# Altium schematic sheet PISCES_200.SchDoc(PISCES_200)
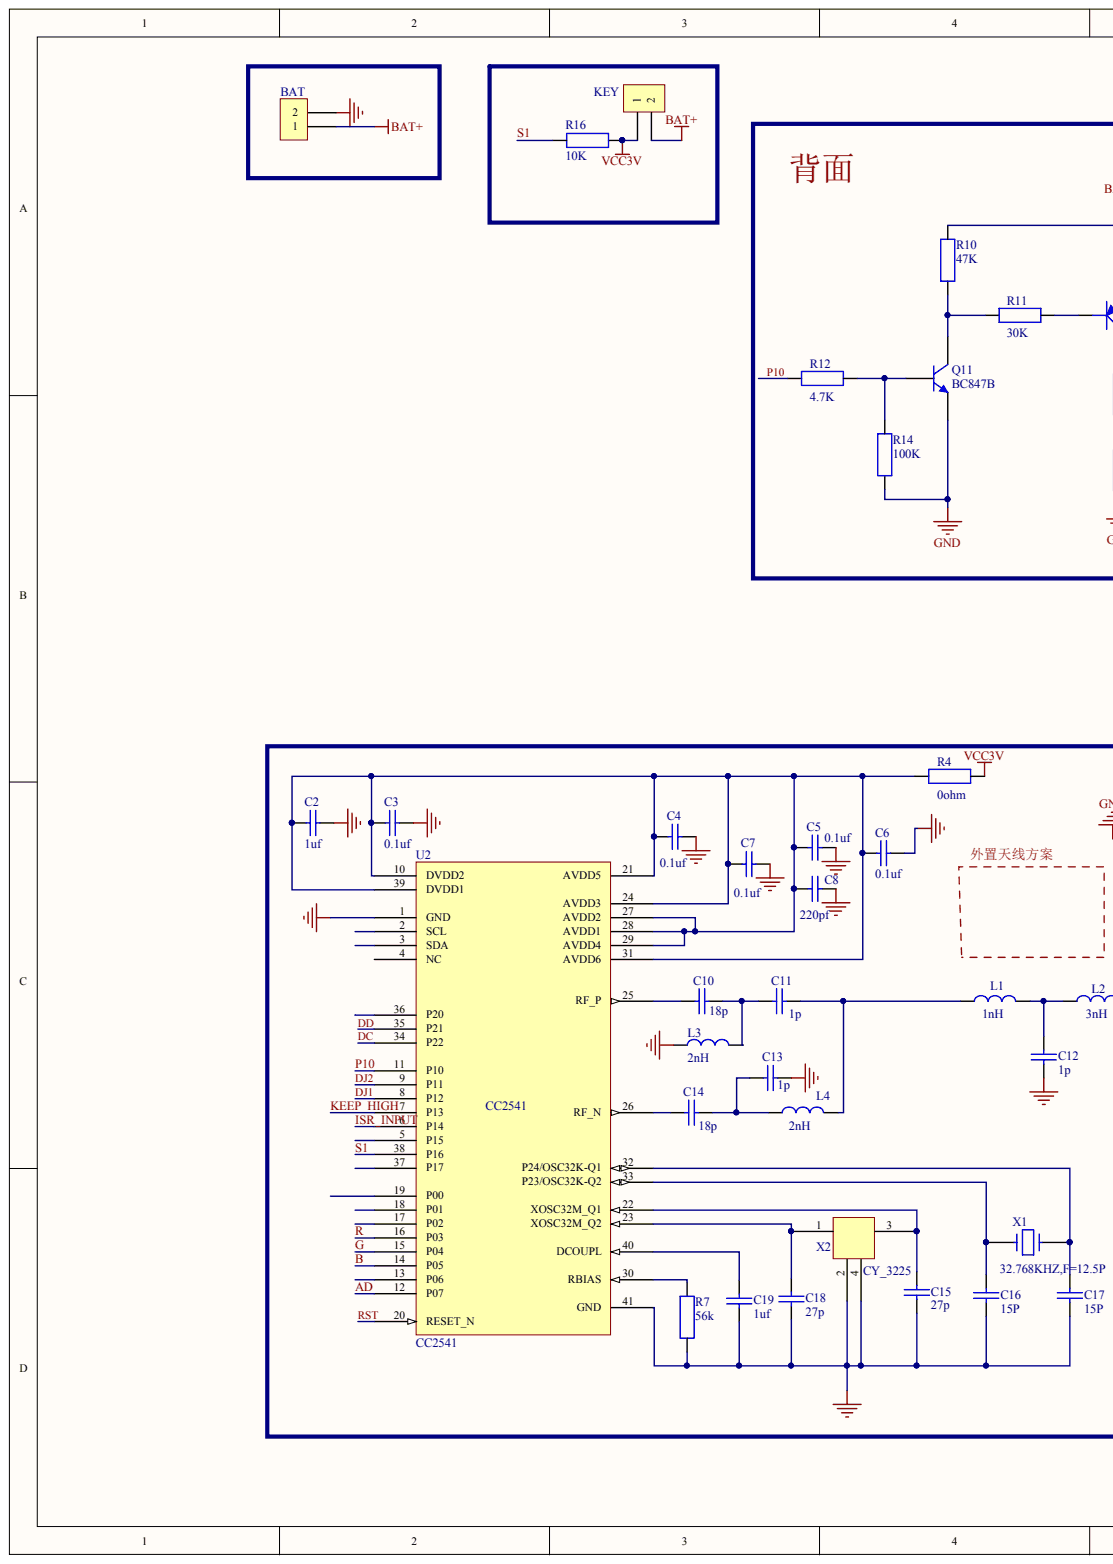
[diagram p0: PISCES_200.SchDoc, left]
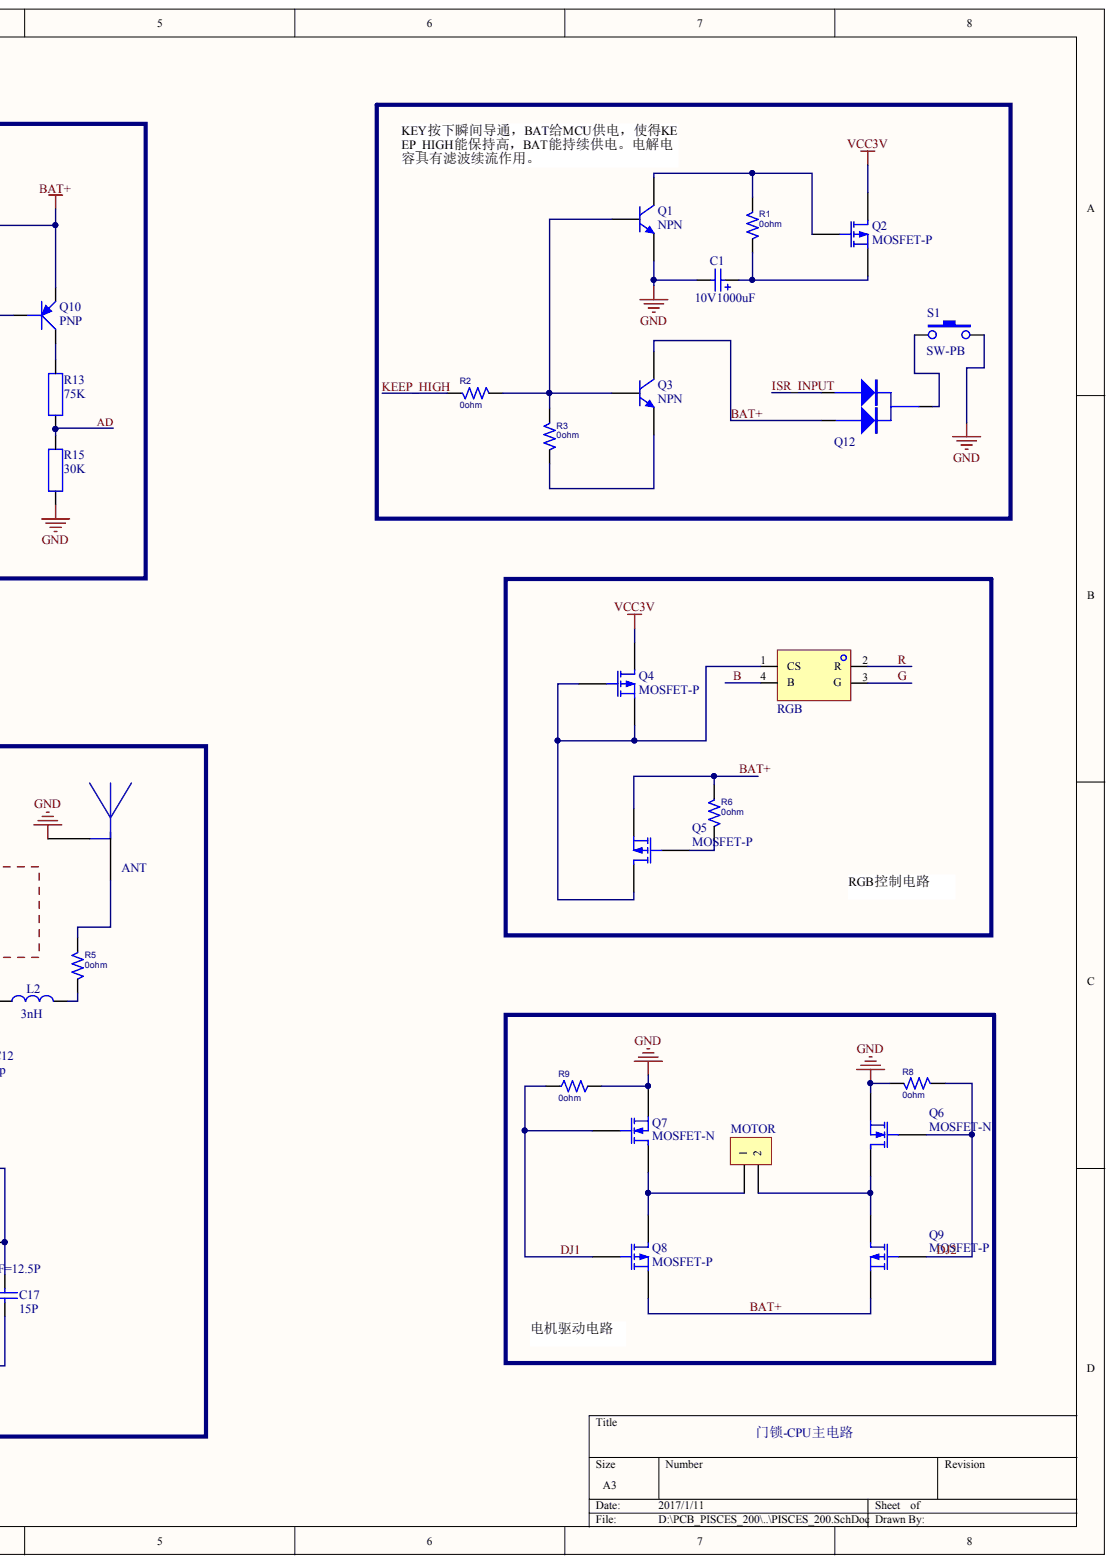
[diagram p1: PISCES_200.SchDoc, right]

## Parts
ANT  ANT  [ANT]
BAT  CN1  [2PAD]  (value not drawn)
C1  10V  value 1000uF  [CAT_ELE]
C2  1uf  [PC5-*****-**-0A_0603_TDK]
C3  0.1uf  [PC5-*****-**-0A_0603_TDK]
C4  0.1uf  [PC5-*****-**-0A_0603_TDK]
C5  0.1uf  [PC7-*****-KQA0A_0402_YAGEO]
C6  0.1uf  [PC7-*****-KQA0A_0402_YAGEO]
C7  0.1uf  [PC7-*****-KQA0A_0402_YAGEO]
C8  220pf  [PC7-*****-KQA0A_0402_YAGEO]
C10  18p  [PC7-*****-KQA0A_0402_YAGEO]
C11  1p  [PC7-*****-KQA0A_0402_YAGEO]
C12  1p  [PC7-*****-KQA0A_0402_YAGEO]
C13  1p  [PC7-*****-KQA0A_0402_YAGEO]
C14  18p  [PC7-*****-KQA0A_0402_YAGEO]
C15  27p  [PC7-*****-KO-0A_0603_TDK]
C16  15P  [PC5-*****-**-0A_0603_TDK]
C17  15P  [PC7-*****-KO-0A_0603_TDK]
C18  27p  [PC7-*****-KO-0A_0603_TDK]
C19  1uf  [PC5-*****-**-0A_0603_TDK]
KEY  CN1  [KEY_2P]  (value not drawn)
L1  1nH  [PC5-*****-**-0A_0402_TDK]
L2  3nH  [PC5-*****-**-0A_0402_TDK]
L3  2nH  [PC5-*****-**-0A_0402_TDK]
L4  2nH  [PC5-*****-**-0A_0402_TDK]
MOTOR  CN1  [2PAD]  (value not drawn)
Q1  NPN  [SOT-23]
Q2  MOSFET-P  [SOT-23]
Q3  NPN  [SOT-23]
Q4  MOSFET-P  [SOT-23]
Q5  MOSFET-P  [SOT-23]
Q6  MOSFET-N  [SOT-23]
Q7  MOSFET-N  [SOT-23]
Q8  MOSFET-P  [SOT-23]
Q9  MOSFET-P  [SOT-23]
Q10  PNP  [SOT-23]
Q11  BC847B  [SOT-23]
Q12  Q12  [SOT-23]
R1  0ohm  [PC7-*****-KQA0A_0402_YAGEO]
R2  0ohm  [PC7-*****-KQA0A_0402_YAGEO]
R3  0ohm  [PC7-*****-KQA0A_0402_YAGEO]
R4  0ohm  [PC5-*****-**-0A_0603_TDK]
R5  0ohm  [PC7-*****-KQA0A_0402_YAGEO]
R6  0ohm  [PC7-*****-KQA0A_0402_YAGEO]
R7  56k  [PRC-*****-BM-0A_0402]
R8  0ohm  [PC7-*****-KQA0A_0402_YAGEO]
R9  0ohm  [PC7-*****-KQA0A_0402_YAGEO]
R10  47K  [PC5-*****-**-0A_0603_TDK]
R11  30K  [PC5-*****-**-0A_0603_TDK]
R12  4.7K  [PC5-*****-**-0A_0603_TDK]
R13  75K  [PC5-*****-**-0A_0603_TDK]
R14  100K  [PC5-*****-**-0A_0603_TDK]
R15  30K  [PC5-*****-**-0A_0603_TDK]
R16  10K  [PC5-*****-**-0A_0603_TDK]
RGB  RGB
S1  SW-PB  [TS-030]
U2  CC2541  [CC2530_QFN40]
X1  32.768KHZ,F=12.5P  [FC-135]
X2  CY_3225  [CY_3225]

## Nets
GND: ANT.2 BAT.2 C1.2 C2.2 C3.2 C4.2 C5.2 C6.2 C7.2 C8.1 C12.1 C13.2 C15.1 C16.1 C17.1 C18.1 C19.1 L3.1 Q1.2 Q6.2 Q7.2 Q11.2 R7.2 R8.2 R9.2 R14.1 R15.1 S1.2 U2.1(GND) U2.41(GND) X2.2 X2.4
VCC3V: KEY.1 Q2.3 Q4.3 R4.2 R16.1
AD: R13.1 R15.2 U2.12(P07)
B: RGB.4(B) U2.14(P05)
BAT+: BAT.1 KEY.2 Q3.3 Q5.2 Q8.2 Q9.2 Q10.2 Q12.2 R6.1 R10.2
DC: U2.34(P22)
DD: U2.35(P21)
DJ1: Q7.1 Q8.1 R9.1 U2.8(P12)
DJ2: Q6.1 Q9.1 R8.1 U2.9(P11)
G: RGB.3(G) U2.15(P04)
ISR_INPUT: Q12.1 U2.6(P14)
KEEP_HIGH: R2.1 U2.7(P13)
P10: R12.1 U2.11(P10)
R: RGB.2(R) U2.16(P03)
RST: U2.20(RESET_N)
S1: R16.2 U2.38(P16)
NetANT_1: ANT.1 R5.1
NetC1_1: C1.1 Q2.2 R1.2
NetC2_1: C2.1 C3.1 C4.1 C5.1 C6.1 C7.1 C8.2 R4.1 U2.10(DVDD2) U2.21(AVDD5) U2.24(AVDD3) U2.27(AVDD2) U2.28(AVDD1) U2.29(AVDD4) U2.31(AVDD6) U2.39(DVDD1)
NetC10_1: C10.1 U2.25(RF_P)
NetC10_2: C10.2 C11.1 L3.2
NetC11_2: C11.2 L1.1 L4.2
NetC12_2: C12.2 L1.2 L2.1
NetC13_1: C13.1 C14.2 L4.1
NetC14_1: C14.1 U2.26(RF_N)
NetC15_2: C15.2 U2.22(XOSC32M_Q1) X2.3
NetC16_2: C16.2 U2.33(P23/OSC32K-Q2) X1.1
NetC17_2: C17.2 U2.32(P24/OSC32K-Q1) X1.2
NetC18_2: C18.2 U2.23(XOSC32M_Q2) X2.1
NetC19_2: C19.2 U2.40(DCOUPL)
NetL2_2: L2.2 R5.2
NetMOTOR_1: MOTOR.1 Q7.3 Q8.3
NetMOTOR_2: MOTOR.2 Q6.3 Q9.3
NetQ1_1: Q1.1 Q3.1 R2.2 R3.1
NetQ1_3: Q1.3 Q2.1 R1.1
NetQ3_2: Q3.2 R3.2
NetQ4_1: Q4.1 Q4.2 Q5.3 RGB.1(CS)
NetQ5_1: Q5.1 R6.2
NetQ10_1: Q10.1 R11.2
NetQ10_3: Q10.3 R13.2
NetQ11_1: Q11.1 R12.2 R14.2
NetQ11_3: Q11.3 R10.1 R11.1
NetQ12_3: Q12.3 S1.1
NetR7_1: R7.1 U2.30(RBIAS)
N00012:
N00013:
N00014:
N00015:
背面:

Not connected: U2 2(SCL) 3(SDA) 4(NC) 5(P15) 13(P06) 17(P02) 18(P01) 19(P00) 36(P20) 37(P17)

## Library data of the parts
BAT KEY MOTOR = CON2: Connector; Component Kind: Standard; Library Name: BJC.SCHLIB
C1 = Cap Pol2: Polarized Capacitor (Axial); Component Kind: Standard; LatestRevisionDate: 17-Jul-2002; LatestRevisionNote: Re-released for DXP Platform.; Library Name: Miscellaneous Devices.SchLib; PackageDescription: Polarized Capacitor; 2 Leads; PackageReference: POLAR0.8; Published: 8-Jun-2000; Publisher: Altium Limited
C2-C8 C10-C19 = PC7_0402_YAGEO: PC7-*****-KQA0A; Component Kind: Standard; Library Name: Schlib.SCHLIB
L1-L4 = PWL_18nH: PWL-00195-00-20; Component Kind: Standard; Library Name: Schlib.SCHLIB
Q1 Q3 Q11 = NPN: BC847C; Code_JEDEC: TO-226-AA; Component Kind: Standard; LatestRevisionDate: 17-Jul-2002; LatestRevisionNote: Re-released for DXP Platform.; PackageDescription: TO, Flat Index; 3 In-Line, Axial Leads; Body Dia. 4.8mm; Leads 0.55 x 0.51 mm (max); PackageReference: TO-226-AA; Published: 8-Jun-2000; Library Name: PISCES_200.SCHLIB; Publisher: Altium Limited
Q2 Q4 Q5 Q8 Q9 = MOSFET-P: P-Channel MOSFET; Component Kind: Standard; LatestRevisionDate: 17-Jul-2002; LatestRevisionNote: Re-released for DXP Platform.; PackageDescription: TO, Flat Index; 3 In-Line, Axial Leads; Body Dia. 4.6mm; Lead Dia. 0.5 mm (max); PackageReference: E3; PackageVersion: 1995/1996; Published: 8-Jun-2000; Library Name: PISCES_200.SCHLIB; Publisher: Altium Limited
Q6 Q7 = MOSFET-N: N-Channel MOSFET; Component Kind: Standard; LatestRevisionDate: 17-Jul-2002; LatestRevisionNote: Re-released for DXP Platform.; PackageDescription: TO, Flat Index; 3 In-Line, Axial Leads; Body Dia. 4.6mm; Lead Dia. 0.5 mm (max); PackageReference: E3; PackageVersion: 1995/1996; Published: 8-Jun-2000; Library Name: PISCES_200.SCHLIB; Publisher: Altium Limited
Q10 = PNP: BC857C; Component Kind: Standard; Library Name: PISCES_200.SCHLIB
Q12 = BAT54C: Component Kind: Standard; Library Name: PISCES_200.SCHLIB
R1-R3 R5 R6 R8 R9 = R_Dup3: Bottom: 20; PSpiceTemplate: R^@REFDES %1 %2 ?TOLERANCE|R^@REFDES| @VALUE  ?TOLERANCE|\n.model R^@REFDES RES R=1 DEV=@TOLERANCE%|; Component Kind: Standard; Right: 20
R4 R7 R10-R16 = PRC_0603: PRC-*****-AM-0A_0603; Text Field1: pristel=\Models.lib; Component Kind: Standard; Library Name: Schlib.SCHLIB
X1 = CRYSTAL: Component Kind: Standard; Description: Crystal; Library Name: CC254xEM原理图库.lib
ANT = ANT: Component Kind: Standard; Library Name: BT100_Project.SCHLIB
RGB = RGB: Component Kind: Standard; Library Name: PISCES_200.SCHLIB
S1 = SW-PB: Component Kind: Standard; Library Name: LG_SCH.SCHLIB
U2 = CC2541: Component Kind: Standard
X2 = CY_3225: Component Kind: Standard; Library Name: CC254xEM原理图库.lib
